# Altium schematic sheet schematic.SchDoc
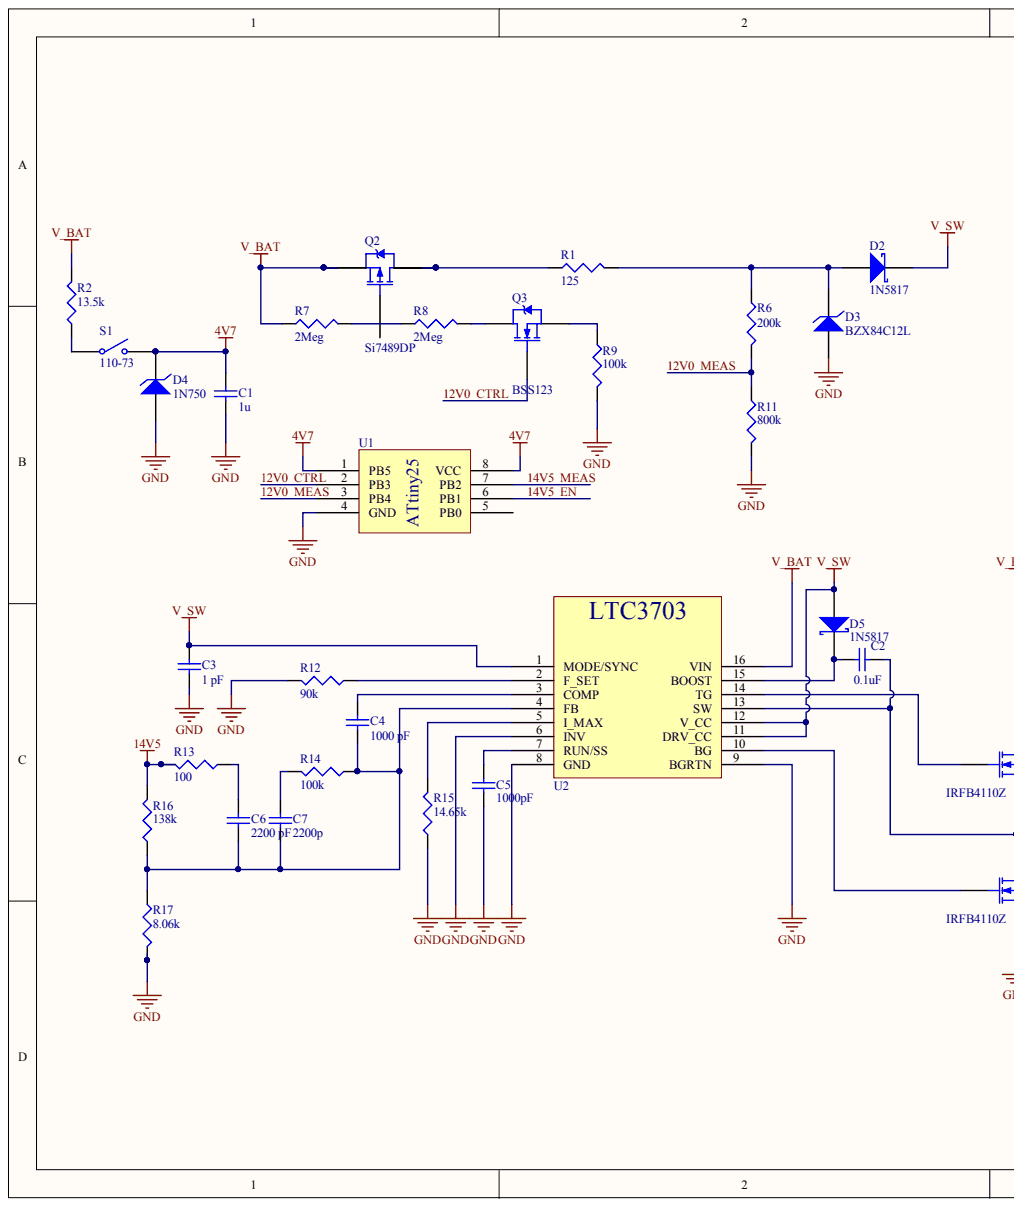
[diagram p0: schematic.SchDoc, left]
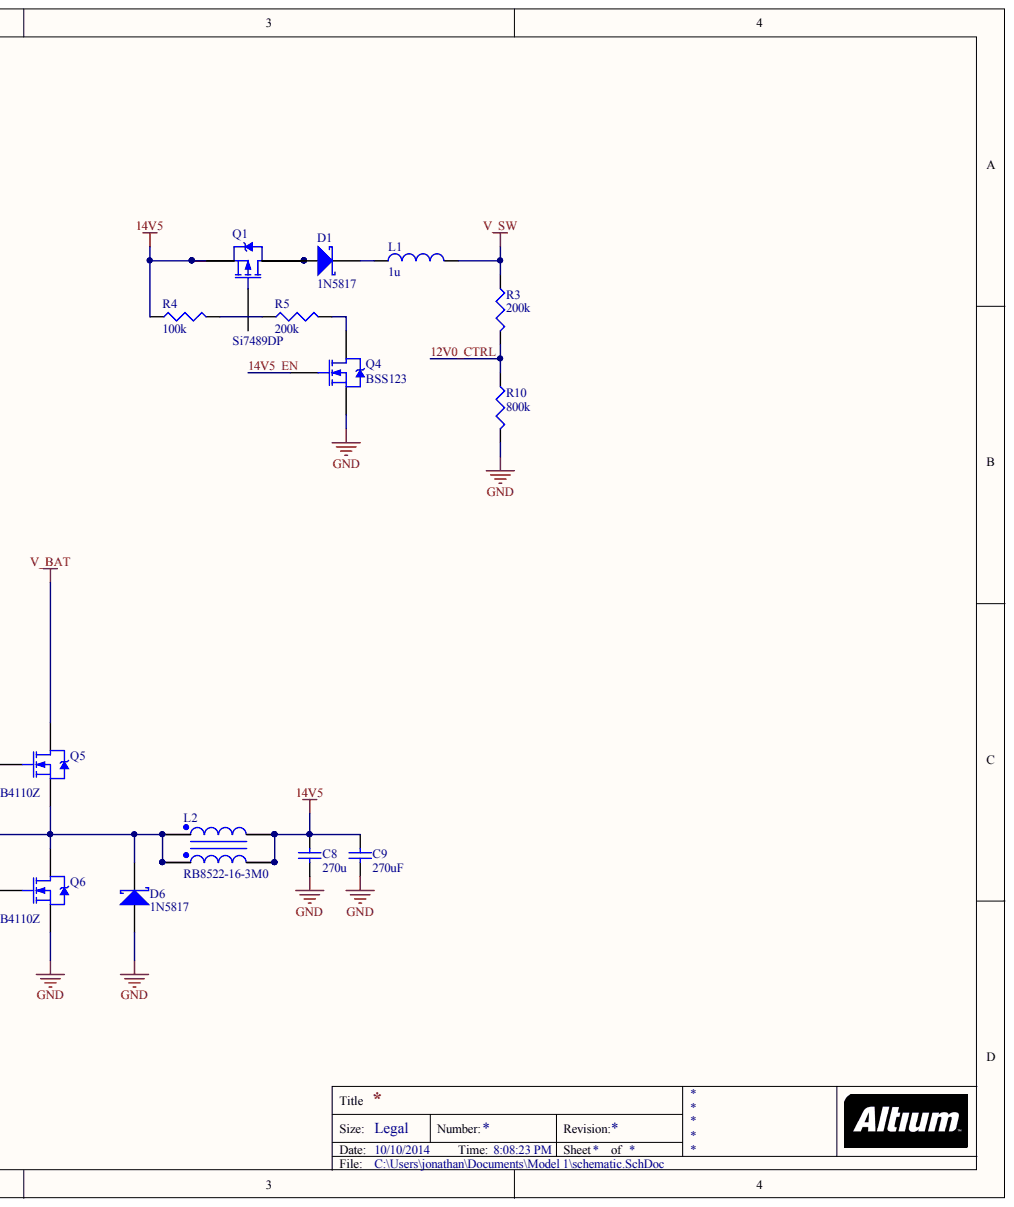
[diagram p1: schematic.SchDoc, right]

## Parts
C1  value 1u  [CAP0805]  (hidden comment: Capacitor)
C2  value 0.1uF  [CAP0805]  (hidden comment: Capacitor)
C3  value 1 pF  [CAP0805]  (hidden comment: Capacitor)
C4  value 1000 pF  [CAP0805]  (hidden comment: Capacitor)
C5  value 1000pF  [CAP0805]  (hidden comment: Capacitor)
C6  value 2200 pF  [CAP0805]  (hidden comment: Capacitor)
C7  value 2200p  [CAP0805]  (hidden comment: Capacitor)
C8  value 270u  [CAP0805]  (hidden comment: Capacitor)
C9  value 270uF  [CAP0805]  (hidden comment: Capacitor)
D1  1N5817  [DO-41]
D2  1N5817  [DO-41]
D3  BZX84C12L  [3-Lead SOT-23]
D4  1N750  [DO-35]
D5  1N5817  [DO-41]
D6  1N5817  [DO-41]
L1  value 1u  (hidden comment: Inductor)
L2  RB8522-16-3M0  [RB8522-16-3M0]
Q1  Si7489DP  [PowerPAK SO-8]
Q2  Si7489DP  [PowerPAK SO-8]
Q3  BSS123  [3-Lead SOT-23]
Q4  BSS123  [3-Lead SOT-23]
Q5  IRFB4110Z  [TO-220]
Q6  IRFB4110Z  [TO-220]
R1  value 125  [RES1206]  (hidden comment: Resistor)
R2  value 13.5k  [RES1206]  (hidden comment: Resistor)
R3  value 200k  [RES1206]  (hidden comment: Resistor)
R4  value 100k  [RES1206]  (hidden comment: Resistor)
R5  value 200k  [RES1206]  (hidden comment: Resistor)
R6  value 200k  [RES1206]  (hidden comment: Resistor)
R7  value 2Meg  [RES1206]  (hidden comment: Resistor)
R8  value 2Meg  [RES1206]  (hidden comment: Resistor)
R9  value 100k  [RES1206]  (hidden comment: Resistor)
R10  value 800k  [RES1206]  (hidden comment: Resistor)
R11  value 800k  [RES1206]  (hidden comment: Resistor)
R12  value 90k  [RES1206]  (hidden comment: Resistor)
R13  value 100  [RES1206]  (hidden comment: Resistor)
R14  value 100k  [RES1206]  (hidden comment: Resistor)
R15  value 14.65k  [RES1206]  (hidden comment: Resistor)
R16  value 138k  [RES1206]  (hidden comment: Resistor)
R17  value 8.06k  [RES1206]  (hidden comment: Resistor)
S1  110-73  [100-73]
U1  ATtiny25  [PDIP-8]
U2  LTC3703  [SSOP-16]

## Nets
4V7: C1.2 D4.2 S1.2 U1.1(PB5) U1.8(VCC)
14V5: C8.1 C9.1 L2.5 L2.6 L2.7 L2.8 Q1.1 Q1.2 Q1.3 R4.1 R13.2 R16.2
GND: C1.1 C3.1 C5.1 C8.2 C9.2 D3.1 D4.1 D6.2 Q4.3 Q6.3 R9.2 R10.2 R11.2 R12.2 R15.1 R17.2 U1.4(GND) U2.6(INV) U2.8(GND) U2.9(BGRTN)
V_BAT: Q2.1 Q2.2 Q2.3 Q5.2 R2.2 R7.1 U2.16(VIN)
V_SW: C3.2 D2.1 D5.2 L1.2 R3.1 U2.1(MODE/SYNC) U2.11(DRV_CC) U2.12(V_CC)
12V0_CTRL: Q3.1 R3.2 R10.1 U1.2(PB3)
12V0_MEAS: R6.2 R11.1 U1.3(PB4)
14V5_EN: Q4.1 U1.6(PB1)
14V5_MEAS: U1.7(PB2)
NetC2_1: C2.1 D5.1 U2.15(BOOST)
NetC2_2: C2.2 D6.1 L2.1 L2.2 L2.3 Q5.3 Q6.2 U2.13(SW)
NetC4_1: C4.1 C6.2 C7.1 R14.1 R16.1 R17.1 U2.4(FB)
NetC4_2: C4.2 U2.3(COMP)
NetC5_2: C5.2 U2.7(RUN/SS)
NetC6_1: C6.1 R13.1
NetC7_2: C7.2 R14.2
NetD1_1: D1.1 L1.1
NetD2_2: D2.2 D3.3 R1.2 R6.1
NetQ1_6: Q1.6 Q1.7 Q1.8 Q1.9
NetQ2_6: Q2.6 Q2.7 Q2.8 Q2.9 R1.1
NetQ3_2: Q3.2 R8.2
NetQ3_3: Q3.3 R9.1
NetQ4_2: Q4.2 R5.2
NetQ5_1: Q5.1 U2.14(TG)
NetQ6_1: Q6.1 U2.10(BG)
NetR2_1: R2.1 S1.1
NetR4_2: R4.2 R5.1
NetR7_2: R7.2 R8.1
NetR12_1: R12.1 U2.2(F_SET)
NetR15_2: R15.2 U2.5(I_MAX)

Not connected: D1 2; L2 4; Q1 4 5; Q2 4 5; U1 5(PB0)

## Library data of the parts
C6 = Capacitor: Value: 2200 pF; Component Kind: Standard; Library Name: company parts.IntLib
C1-C5 C7-C9 = Capacitor: Component Kind: Standard; Library Name: company parts.IntLib
D1 D2 D5 D6 = 1N5817: Component Kind: Standard; Library Name: company parts.IntLib
D3 = BZX84C12L: Component Kind: Standard; Library Name: company parts.IntLib
D4 = 1N750: Component Kind: Standard; Library Name: company parts.IntLib
L1 = Inductor: Component Kind: Standard; Library Name: company parts.IntLib
L2 = RB8522-16-3M0: Component Kind: Standard; Library Name: company parts.IntLib
Q1 Q2 = SI7489DP: Component Kind: Standard; Library Name: company parts.IntLib
Q3 Q4 = BSS123: Component Kind: Standard; Library Name: company parts.IntLib
Q5 Q6 = IRFB4110Z: Component Kind: Standard; Library Name: company parts.IntLib
R1-R17 = Resistor: Component Kind: Standard; Library Name: company parts.IntLib
S1 = 110-73: Component Kind: Standard; Library Name: company parts.IntLib
U1 = ATtiny25: Component Kind: Standard; Library Name: company parts.IntLib
U2 = LTC3703: Component Kind: Standard; Library Name: company parts.IntLib
